# Altium schematic sheet PowerStage.SchDoc
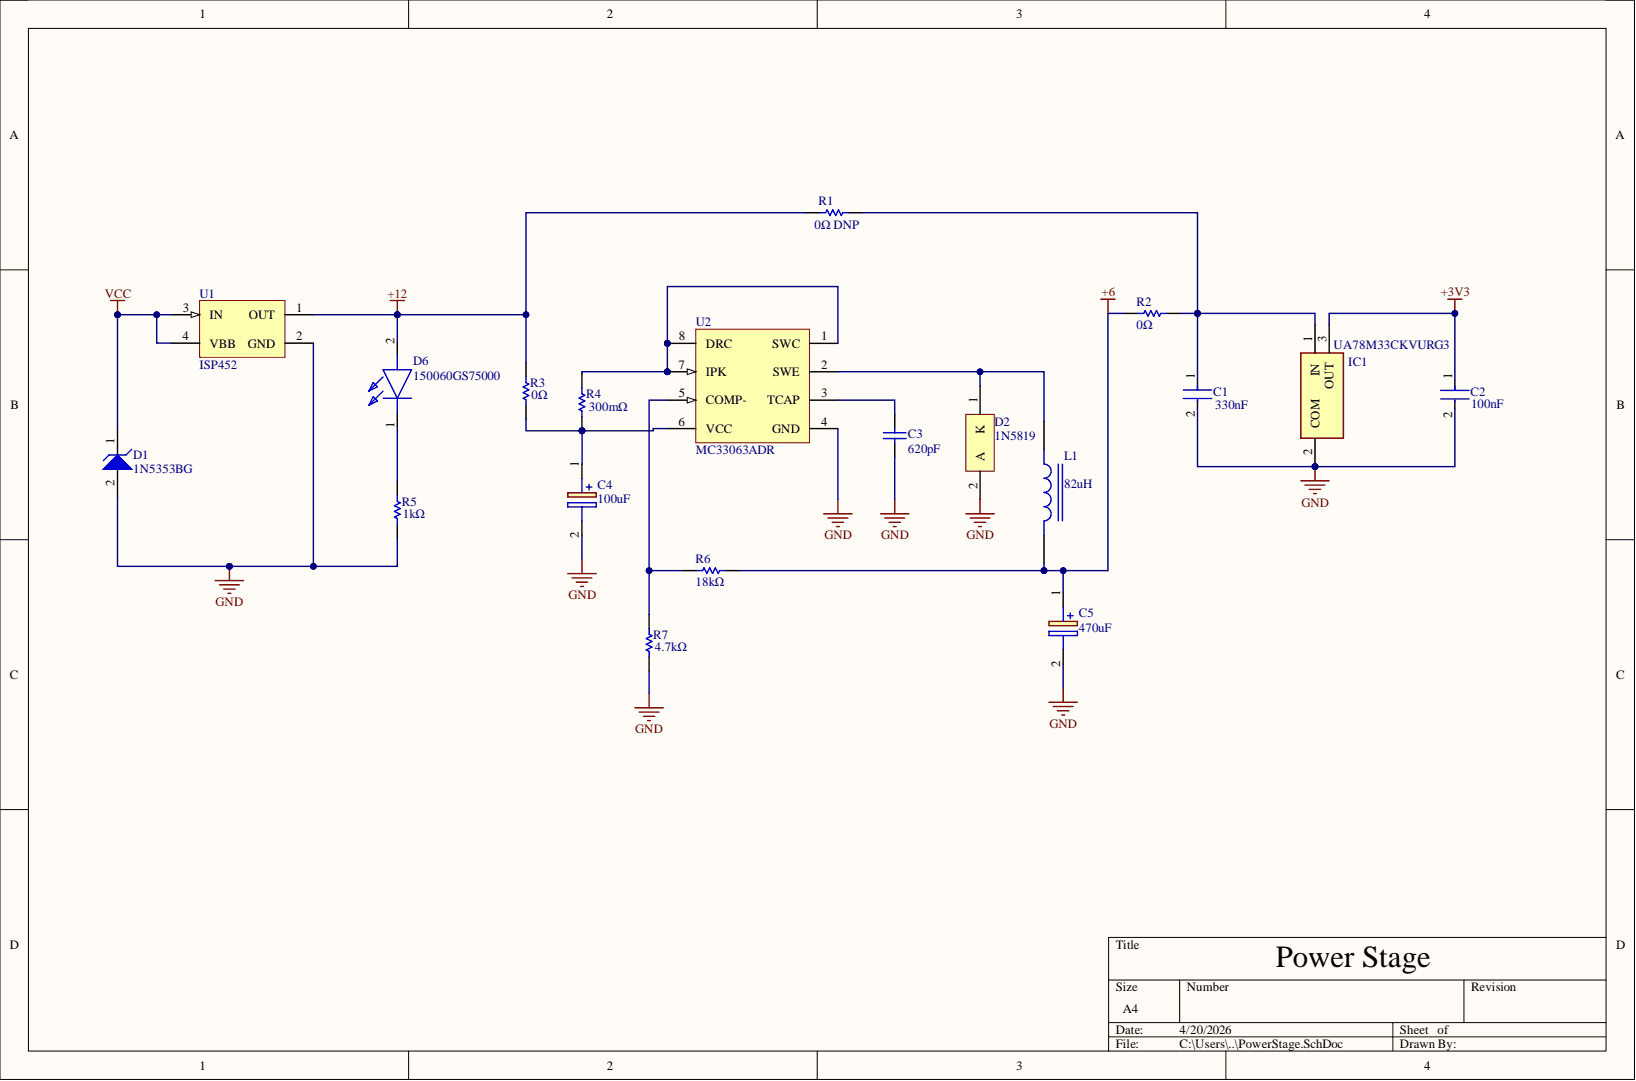
[diagram p0: PowerStage.SchDoc]

## Parts
C1  Capacitance: 330nF  [FP-0603-L_1_6_0_1-W_0_8_0-IPC_A]  (hidden comment: 0.33uF)
C2  Capacitance: 100nF  [FP-0603-L_1_6_0_1-W_0_8_0-IPC_A]  (hidden comment: 0.1uiF)
C3  620pF  [CAPC1206(3216)100_M]
C4  value 100uF  [CAPAE660X820N]  (hidden comment: EMZR500ARA101MF80G)
C5  value 470uF  [CAPAE830X1050N]  (hidden comment: EMZS250ARA471MHA0G)
D1  1N5353BG  [ONSC-SURMETIC40-2-017AA-01]
D2  1N5819  [ONSC-AXIAL_LEAD-2-59-10_P]
D6  150060GS75000  [LEDC1608X80N]
IC1  UA78M33CKVURG3  [TPS7A6533QKVURQ1]
L1  82uH  [IND_SRN8040TA-820M]
R1  DNP  Resistance: 0Ω DNP  [RESC1608X55X30ML20T10]
R2  Resistance: 0Ω  [RESC1608X55X30ML20T10]  (hidden comment: 100)
R3  Resistance: 0Ω  [RESC1608X55X30ML20T10]  (hidden comment: 100)
R4  value 300mΩ  [RESC1206(3216)_M]  (hidden comment: 0.3)
R5  Resistance: 1kΩ  [RESC1608X55X30ML20T10]  (hidden comment: 100)
R6  Resistance: 18kΩ  [RESC1608X55X30ML20T10]  (hidden comment: 100)
R7  Resistance: 4.7kΩ  [RESC1608X55X30ML20T10]  (hidden comment: 100)
U1  ISP452  [INF-SOT223_L]
U2  MC33063ADR  [D0008A_M]

## Nets
+3V3: C2.1 IC1.3
+6: C5.1 L1.2 R2.1 R6.2
+12: D6.2 R1.1 R3.1 U1.1(OUT)
GND: C1.2 C2.2 C3.1 C4.2 C5.2 D1.2 D2.2 IC1.2 R5.2 R7.2 U1.2(GND) U2.4(GND)
VCC: D1.1 U1.3(IN) U1.4(VBB)
NetC1_1: C1.1 IC1.1 R1.2 R2.2
NetC3_2: C3.2 U2.3(TCAP)
NetC4_1: C4.1 R3.2 R4.1 U2.6(VCC)
NetD2_1: D2.1 L1.1 U2.2(SWE)
NetD6_1: D6.1 R5.1
NetR4_2: R4.2 U2.1(SWC) U2.7(IPK) U2.8(DRC)
NetR6_1: R6.1 R7.1 U2.5(COMP-)

## Library data of the parts
C1 C2 = CMP-14477-003254-2: None; Dielectric Material: Ceramic; Tolerance: 10%; Voltage: 50V; Case Code (Imperial): 0603; Case Code (Metric): 1608; Case/Package: 0603; Component Kind: Standard; Construction: Flat; Depth: 0.8mm; Halogen Free: Halogen Free; Height: 0.8mm; Lead Free: Lead Free; Length: 1.6mm; Library Name: Altium Content Vault; Max Operating Temperature: 125°C; Min Operating Temperature: -55°C; Mount: Surface Mount; Number of Pins: 2; Package Quantity: 10; Packaging: Tape and Reel; Radiation Hardening: No; RoHS Compliant: Yes; Series: CFCAP™; Termination: SMD/SMT; Thickness: 0.035inch; Voltage Rating: 50V; Voltage Rating (DC): 50V; Weight: 7.1E-05oz; Width: 0.031inch
C3 = CMP-1037-02023-2: CAP 620pF 200V ±5% 1206 (3216 Metric) Thickness 1mm SMD; Case-EIA: 1206; Case-Metric: 3216; Tolerance: Â±5%; Component Kind: Standard; Library Name: Altium Content Vault; Max Thickness: 1 mm; Rated Voltage: 200 V; Technology: SMT; Value: 620pF
C4 = EMZR500ARA101MF80G: Capacitor Polarised; Manufacturer_Name: Chemi-Con; Arrow Part Number: EMZR500ARA101MF80G; Arrow Price/Stock: https://www.arrow.com/en/products/emzr500ara101mf80g/united-chemi-con?region=nac; Component Kind: Standard; Datasheet Link: https://datasheet.datasheetarchive.com/originals/distributors/DKDS-39/4980.pdf; Height: 8.2mm; Manufacturer_Part_Number: EMZR500ARA101MF80G; Mouser Part Number: 661-EMZR500ARA101MF8; Mouser Price/Stock: https://www.mouser.co.uk/ProductDetail/Chemi-Con/EMZR500ARA101MF80G?qs=y6ZabgHbY%252ByQ3zUEIlMmqw%3D%3D
C5 = EMZS250ARA471MHA0G: Capacitor Polarised; Manufacturer_Name: Chemi-Con; Arrow Part Number: EMZS250ARA471MHA0G; Arrow Price/Stock: https://www.arrow.com/en/products/emzs250ara471mha0g/united-chemi-con?region=nac; Component Kind: Standard; Datasheet Link: https://www.mouser.cn/datasheet/2/420/al-mzs-bulletin875a-e-171012-1323330.pdf; Height: 10.5mm; Manufacturer_Part_Number: EMZS250ARA471MHA0G; Mouser Part Number: 661-EMZS250ARA471MHA; Mouser Price/Stock: https://www.mouser.co.uk/ProductDetail/Chemi-Con/EMZS250ARA471MHA0G?qs=y6ZabgHbY%252BwxlheNLIFQWQ%3D%3D
D1 = CMP-1060-01290-1: 5 W Zener Voltage Regulator, 2-Pin Surmetic(TM) 40, Pb-Free, Bulk Box; Manufacturer: On Semiconductor; P Max (W): 5; VZ Typ (V): 16; Component Kind: Standard; ComponentLink1Description: Manufacturer URL; ComponentLink1URL: http://www.onsemi.com/; ComponentLink2Description: Datasheet; ComponentLink2URL: http://www.onsemi.cn/pub_link/Collateral/1N5333B-D.PDF; ComponentLink3Description: Package Specification; ComponentLink3URL: http://www.onsemi.cn/pub_link/Collateral/017AA.PDF; DatasheetVersion: Rev. 14; Manufacturer Part Number: 1N5353BG; Mounting Technology: Through Hole; PackageDescription: 2-Pin, Axial Lead, Diameter 3.49 mm; PackageReference: SURMETIC40-2-017AA-01; PackageVersion: Rev. O, 09/2005; Packing: Bulk Box; PartNumber: 1N5353BG; RoHS: Pb-Free; Library Name: ePDM_V0.0.SCHLIB
D2 = CMP-1055-00042-1: Rectifier, 2-Pin Axial_Lead, Pb-Free, Bulk Bag; Manufacturer: On Semiconductor; Configuration: Single; IFSM Max (A): 25; Note1: 0; Component Kind: Standard; ComponentLink1Description: Manufacturer URL; ComponentLink1URL: http://www.onsemi.com/; ComponentLink2Description: Datasheet; ComponentLink2URL: http://www.onsemi.cn/pub_link/Collateral/1N5817-D.PDF; ComponentLink3Description: Package Specification; ComponentLink3URL: http://www.onsemi.cn/pub_link/Collateral/59-10.PDF; DatasheetVersion: Rev. 10; Library Name: Altium Content Vault; Mounting Technology: Through Hole; PackageDescription: 2-Pin, Axial Lead, Diameter 2.35 mm; PackageReference: AXIAL_LEAD-2-59-10; PackageVersion: Rev. U, 02/2005; Packing: Bulk Bag; PartNumber: 1N5819; RoHS: TRUE
D6 = 150060GS75000: LED; Manufacturer_Name: Wurth Elektronik; Component Kind: Standard; Datasheet Link: https://componentsearchengine.com/Datasheets/2/150060GS75000.pdf; Height: 0.8mm; Manufacturer_Part_Number: 150060GS75000; Mouser Part Number: 710-150060GS75000; Mouser Price/Stock: https://www.mouser.co.uk/ProductDetail/Wurth-Elektronik/150060GS75000?qs=LlUlMxKIyB0UYkq5lDO8nA%3D%3D; Library Name: ePDM_V0.0.SCHLIB
IC1 = UA78M33CKVURG3: Integrated Circuit; Manufacturer_Name: Texas Instruments; Arrow Part Number: UA78M33CKVURG3; Arrow Price/Stock: https://www.arrow.com/en/products/ua78m33ckvurg3/texas-instruments?region=nac; Component Kind: Standard; Datasheet Link: https://www.ti.com/lit/gpn/ua78m?HQS=ti-null-null-sf-df-pf-sep-wwe&DCM=yes; Height: 2.52mm; Manufacturer_Part_Number: UA78M33CKVURG3; Mouser Part Number: 595-UA78M33CKVURG3; Mouser Price/Stock: https://www.mouser.co.uk/ProductDetail/Texas-Instruments/UA78M33CKVURG3?qs=0O%2FZFlpUpJXrDg4gfD1Q2g%3D%3D
L1 = SRN8040TA-820M: Inductor Power Semi-Shielded Wirewound 82uH 20% 1MHz 10Q-Factor Ferrite 1.3A 410mOhm DCR 3131 Automotive T/R; MF: {'manufacturer': u'Bourns'}; Availability: Unavailable; Component Kind: Standard; MP: SRN8040TA-820M; Package: None; Price: None; Value: 82uH
R1-R3 R5-R7 = CMP-2000-01849-1: 0603 Resistor; Manufacturer: KOA Speer; Part Number: RK73B1JTTD101J; Component Kind: Standard; Library Name: Altium Content Vault
R4 = CMP-1014-00113-1: 0.3 0.25W 5% 1206 (3216 Metric)  SMD; Case-EIA: 1206; Case-Metric: 3216; Component Kind: Standard; Library Name: Altium Content Vault; Power: 0.25 W; Technology: SMT; Tolerance: 5 %
U1 = CMP-1095-00141-1: Smart Power High-Side-Switch for Industrial Applications, 5 to 34 V Operating, -30 to 85 degC, SOT223, Reel, Green; Manufacturer: Infineon; Channels: 1; Green: TRUE; IL (nom)min - all channels active: 0.7Â A; IL (nom)min - one channel active: 0.8Â A; IL (SC): 1.5Â A; Operating Voltage Range (max): 34 V; Operating Voltage Range (min): 5 V; Ptot (max): 1.8Â W; RON (max) @ Tj max: 400 mOhm; RON @ 25 degC: 200 mOhm (max); toff (max): 150 us; ton (max): 100 us; Component Kind: Standard; ComponentLink1Description: Manufacturer URL; ComponentLink1URL: http://www.infineon.com/; ComponentLink2Description: Datasheet; ComponentLink2URL: http://www.infineon.com/dgdl/ISP452_20060301.pdf?folderId=db3a304412b407950112b408e8c90004&fileId=db3a304412b407950112b42957ef40c3; ComponentLink3Description: Package Specification; ComponentLink3URL: http://www.infineon.com/dgdl/SOT223-Package_Overview.pdf?folderId=db3a30431936bc4b0119530470b03c3d&fileId=db3a30431936bc4b0119531d40b93c5c; IL (SCp): 1.5Â A; Load Current (typ): 0.7Â A; Manufacturer Part Number: ISP452; Mounting Technology: Surface Mount; PackageDescription: 4-Pin Small Outline Transistor Package, 6.5 x 3.5 mm Body, 2.3 mm Pitch; PackageReference: SOT223; PackageVersion: Apr-08; Packing: Reel; PartNumber: ISP452; Library Name: ePDM_V0.0.SCHLIB
U2 = CMP-0323-00192-3: Inverting Buck-Boost Regulator with 3 to 40 V Input and 1.25 to 40 V Output, -40 to 85 degC, 8-Pin SOIC (D), Green (RoHS & no Sb/Br); Manufacturer: Texas Instruments; Iout(Max)(A): 0.75; Rating: Catalog; Regulated Outputs(#): 1; SubFamily: Step-Down Regulator; Switch Current Limit(Typ)(A): 1.5; Vin(Max)(V): 40; Vin(Min)(V): 3; Vout(Min)(V): 1.25; Code_JEDEC: MS-012AA; Component Kind: Standard; ComponentLink1Description: Datasheet; ComponentLink1URL: http://www.ti.com/general/docs/lit/getliterature.tsp?genericPartNumber=MC33063A&fileType=pdf; ComponentLink2Description: Package Specification; ComponentLink2URL: http://www.ti.com/litv/pdf/msoi002j; ComponentLink3Description: URL Link1; ComponentLink3URL: Samples URL:http://www.ti.com/product/mc33063a#samples; DatasheetVersion: SLLS636M; Eco-Plan: Green (RoHS & no Sb/Br); Library Name: Altium Content Vault; Mounting Technology: Surface Mount; PackageDescription: 8-Pin Plastic Small Outline Integrated Circuit, 4.9 x 3.9 mm, Pitch 1.27 mm Pitch; PackageReference: D0008A; PackageVersion: revM, Jun-2011; PartNumber: MC33063ADR; Topology: Inverting Buck-Boost; Vout(Max)(V): 40
